# Altium schematic sheet Transceiver.SchDoc(Transceiver)
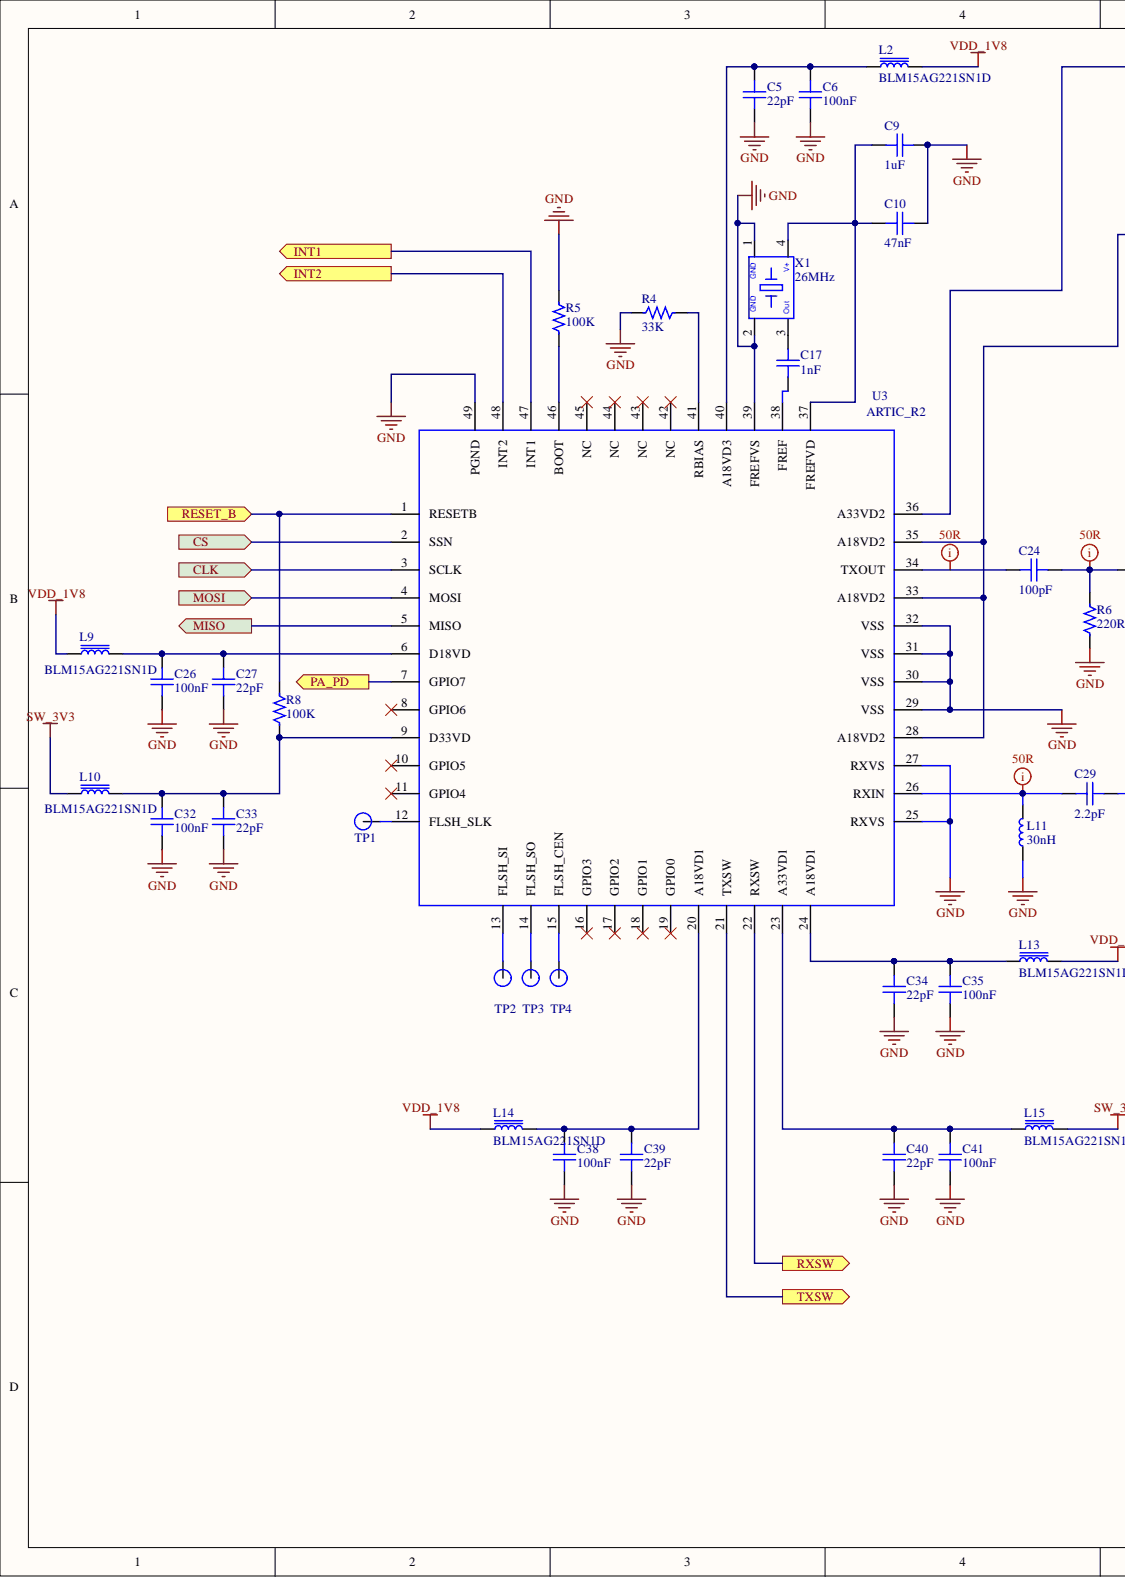
[diagram p0: Transceiver.SchDoc, left]
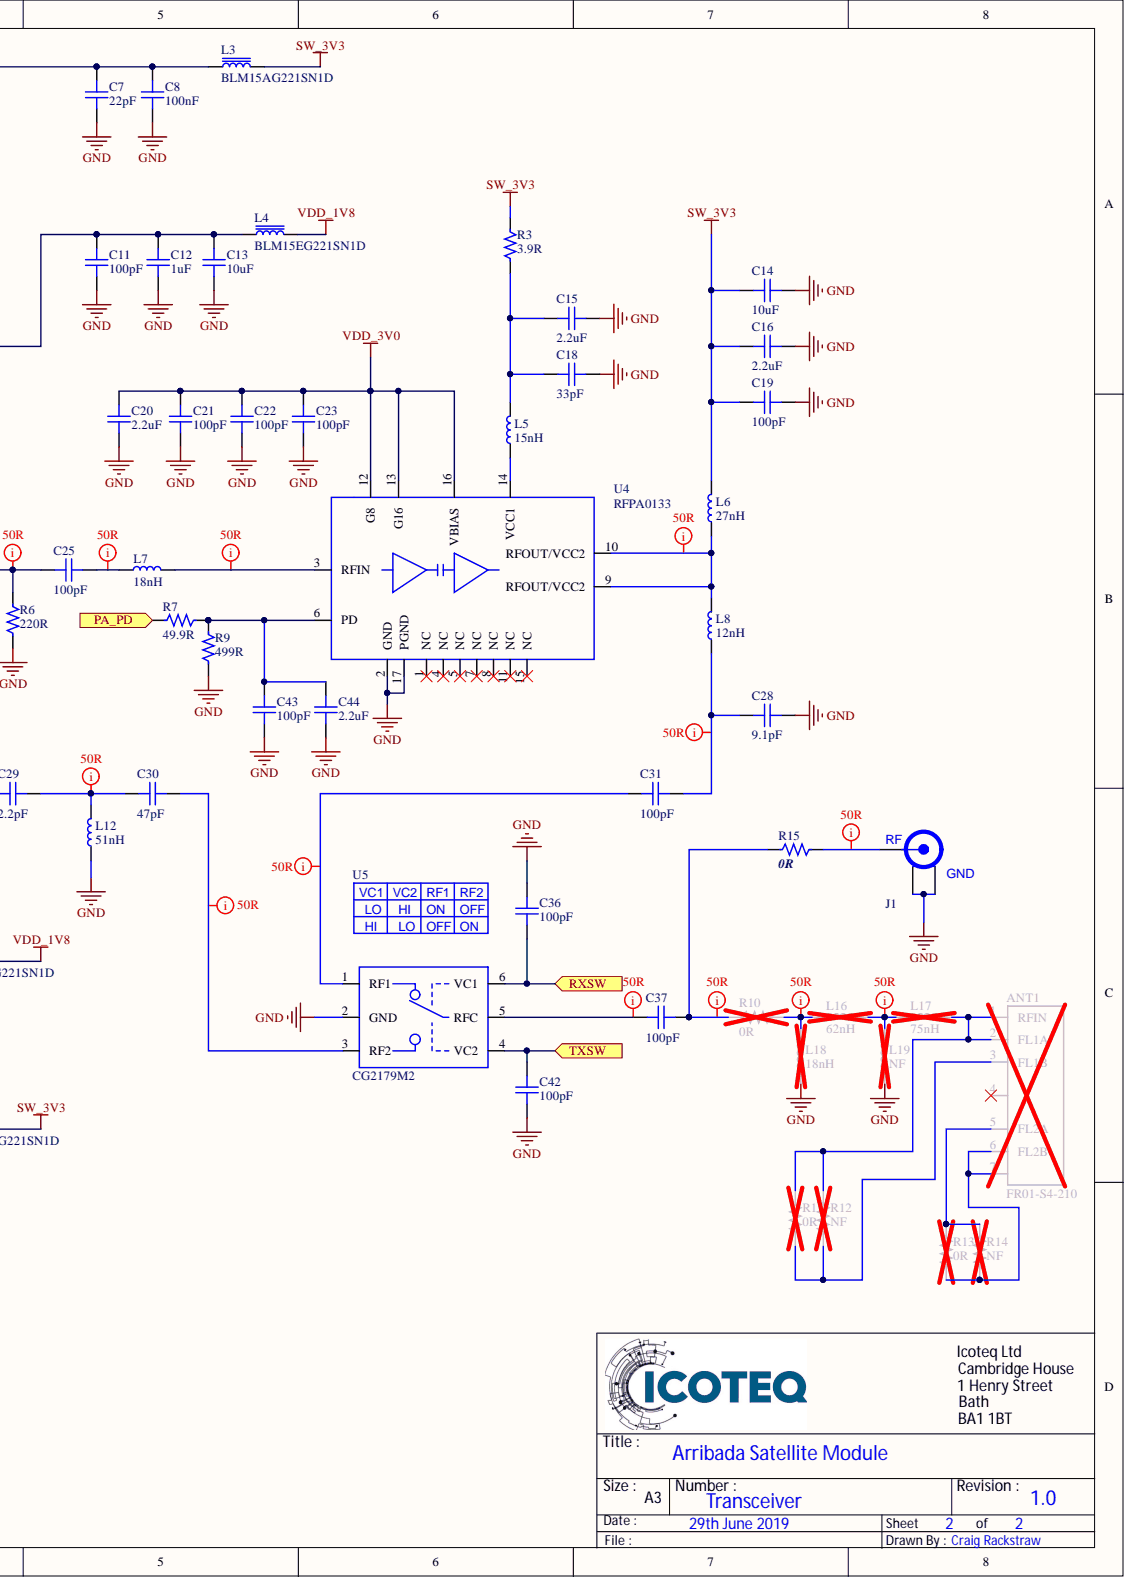
[diagram p1: Transceiver.SchDoc, right]

## Parts
ANT1  FR01-S4-210  [FR01-S4-210]
C5  value 22pF  [CAP0201L]  (hidden comment: CAP CER 22PF 0201 25V 5% C0G)
C6  value 100nF  [CAP0201L]  (hidden comment: 100NF 0201 35V 10% X5R)
C7  value 22pF  [CAP0201L]  (hidden comment: CAP CER 22PF 0201 25V 5% C0G)
C8  value 100nF  [CAP0201L]  (hidden comment: 100NF 0201 35V 10% X5R)
C9  value 1uF  [CAP0201L]  (hidden comment: 1UF 0201 10V 20% X5R)
C10  value 47nF  [CAP0201L]  (hidden comment: 47NF 0201 16V 10% X5R)
C11  value 100pF  [CAP0201L]  (hidden comment: 100PF 0201 25V 5% C0G)
C12  value 1uF  [CAP0201L]  (hidden comment: 1UF 0201 10V 20% X5R)
C13  value 10uF  [CAP0402L]  (hidden comment: 10UF 0402 6.3V 20% X5R)
C14  value 10uF  [CAP0402L]  (hidden comment: 10UF 0402 6.3V 20% X5R)
C15  value 2.2uF  [CAP0201L]  (hidden comment: 2.2UF 0201 6V3 20% X5R)
C16  value 2.2uF  [CAP0201L]  (hidden comment: 2.2UF 0201 6V3 20% X5R)
C17  value 1nF  [CAP0201L]  (hidden comment: 1NF 0201 10V 10% X5R)
C18  value 33pF  [CAP0201L]  (hidden comment: CAP CER 33PF 0201 25V 5% C0G)
C19  value 100pF  [CAP0201L]  (hidden comment: 100PF 0201 25V 5% C0G)
C20  value 2.2uF  [CAP0201L]  (hidden comment: 2.2UF 0201 6V3 20% X5R)
C21  value 100pF  [CAP0201L]  (hidden comment: 100PF 0201 25V 5% C0G)
C22  value 100pF  [CAP0201L]  (hidden comment: 100PF 0201 25V 5% C0G)
C23  value 100pF  [CAP0201L]  (hidden comment: 100PF 0201 25V 5% C0G)
C24  value 100pF  [CAP0402L]  (hidden comment: 100PF 0402 50V 5% C0G)
C25  value 100pF  [CAP0402L]  (hidden comment: 100PF 0402 50V 5% C0G)
C26  value 100nF  [CAP0201L]  (hidden comment: 100NF 0201 35V 10% X5R)
C27  value 22pF  [CAP0201L]  (hidden comment: CAP CER 22PF 0201 25V 5% C0G)
C28  value 9.1pF  [CAP0402L]  (hidden comment: 9.1pF 0402 50V +/-0.25pF C0G)
C29  value 2.2pF  [CAP0402L]  (hidden comment: 2.2pF 0402 50V +/-0.1pF C0G)
C30  value 47pF  [CAP0402L]  (hidden comment: 47pF 0402 50V 5% C0G)
C31  value 100pF  [CAP0402L]  (hidden comment: 100PF 0402 50V 5% C0G)
C32  value 100nF  [CAP0201L]  (hidden comment: 100NF 0201 35V 10% X5R)
C33  value 22pF  [CAP0201L]  (hidden comment: CAP CER 22PF 0201 25V 5% C0G)
C34  value 22pF  [CAP0201L]  (hidden comment: CAP CER 22PF 0201 25V 5% C0G)
C35  value 100nF  [CAP0201L]  (hidden comment: 100NF 0201 35V 10% X5R)
C36  value 100pF  [CAP0201L]  (hidden comment: 100PF 0201 25V 5% C0G)
C37  value 100pF  [CAP0402L]  (hidden comment: 100PF 0402 50V 5% C0G)
C38  value 100nF  [CAP0201L]  (hidden comment: 100NF 0201 35V 10% X5R)
C39  value 22pF  [CAP0201L]  (hidden comment: CAP CER 22PF 0201 25V 5% C0G)
C40  value 22pF  [CAP0201L]  (hidden comment: CAP CER 22PF 0201 25V 5% C0G)
C41  value 100nF  [CAP0201L]  (hidden comment: 100NF 0201 35V 10% X5R)
C42  value 100pF  [CAP0201L]  (hidden comment: 100PF 0201 25V 5% C0G)
C43  value 100pF  [CAP0201L]  (hidden comment: 100PF 0201 25V 5% C0G)
C44  value 2.2uF  [CAP0201L]  (hidden comment: 2.2UF 0201 6V3 20% X5R)
J1  20279-001E-01  [MHF SMT REC]  (value not drawn)
L2  BLM15AG221SN1D  [RES0402L]
L3  BLM15AG221SN1D  [RES0402L]
L4  BLM15EG221SN1D  [RES0402L]
L5  value 15nH  [Coilcraft 0402HP]  (hidden comment: 15nH 2% 1.1A 0402HP)
L6  value 27nH  [Coilcraft 0402HP]  (hidden comment: 27nH 2% 450mA 0402HP)
L7  value 18nH  [LQG15HN_HS]  (hidden comment: 18nH 5% 350MA 0402 MUR)
L8  value 12nH  [Coilcraft 0402HP]  (hidden comment: 12nH 2% 1.2A 0402HP)
L9  BLM15AG221SN1D  [RES0402L]
L10  BLM15AG221SN1D  [RES0402L]
L11  value 30nH  [Coilcraft 0402HP]  (hidden comment: 30nH 2% 450mA 0402HP)
L12  value 51nH  [Coilcraft 0402HP]  (hidden comment: 51nH 2% 360mA 0402HP)
L13  BLM15AG221SN1D  [RES0402L]
L14  BLM15AG221SN1D  [RES0402L]
L15  BLM15AG221SN1D  [RES0402L]
L16  value 62nH  [LQW15A_00/10]  (hidden comment: 62nH 2% 145MA 0402)
L17  value 75nH  [LQW15A_00/10]  (hidden comment: 75nH 2% 135MA 0402)
L18  value 18nH  [LQW15A_00/10]  (hidden comment: 18nH 2% 370MA 0402)
L19  value NF  [LQW15A_00/10]  (hidden comment: LQW15A NF)
R3  value 3.9R  [RES0402L]  (hidden comment: 3.9R 0402 1% 100PPM)
R4  value 33K  [RES0201L]  (hidden comment: 33K 0201 1% 100PPM)
R5  value 100K  [RES0201L]  (hidden comment: 100K 0201 1% 100PPM)
R6  value 220R  [RES0402L]  (hidden comment: 220R 0402 1% 100PPM)
R7  value 49.9R  [RES0201L]  (hidden comment: 49.9R 0201 1% 100PPM)
R8  value 100K  [RES0201N]  (hidden comment: 100K 0201 1% 100PPM)
R9  value 499R  [RES0201L]  (hidden comment: 499R 0201 1% 100PPM)
R10  value 0R  [RES0402L]  (hidden comment: 0R 0402)
R11  value 0R  [RES0402L]  (hidden comment: 0R 0402)
R12  value NF  [Passive0402L Not Fitted]  (hidden comment: RES NF 0402)
R13  value 0R  [RES0402L]  (hidden comment: 0R 0402)
R14  value NF  [Passive0402L Not Fitted]  (hidden comment: RES NF 0402)
R15  value 0R  [RES0402N]  (hidden comment: 0R 0402)
TP1  Test Point Pad 1mm  [TP1MM]  (value not drawn)
TP2  Test Point Pad 1mm  [TP1MM]  (value not drawn)
TP3  Test Point Pad 1mm  [TP1MM]  (value not drawn)
TP4  Test Point Pad 1mm  [TP1MM]  (value not drawn)
U3  ARTIC_R2  [QFN48_7MMx7MM]
U4  RFPA0133  [QFN50P300X300X86_HS-17N]
U5  CG2179M2  [SOT65P210X110-6N]
X1  value 26MHz  [Crystal 1.65mm x 1.25mm]  (hidden comment: LFTCXO073004)

## Ports
CLK, CS, INT1, INT2, MISO, MOSI, PA_PD, RESET_B, RXSW, TXSW

## Nets
GND: C5.1 C6.1 C7.1 C8.1 C9.2 C10.1 C11.1 C12.1 C13.1 C14.1 C15.1 C16.1 C18.2 C19.1 C20.1 C21.1 C22.1 C23.1 C26.1 C27.1 C28.1 C32.1 C33.1 C34.1 C35.1 C36.2 C38.1 C39.1 C40.1 C41.1 C42.1 C43.1 C44.1 J1.2 J1.3 L11.1 L12.1 L18.1 L19.1 R4.2 R5.2 R6.1 R9.1 U3.25(RXVS) U3.27(RXVS) U3.29(VSS) U3.30(VSS) U3.31(VSS) U3.32(VSS) U3.39(FREFVS) U3.49(PGND) U4.2(GND) U4.17(PGND) U5.2(GND) X1.1(GND) X1.2(GND)
SW_3V3: C14.2 C16.2 C19.2 L3.2 L6.2 L10.1 L15.1 R3.2
VDD_1V8: L2.2 L4.2 L9.1 L13.1 L14.1
VDD_3V0: C20.2 C21.2 C22.2 C23.2 U4.12(G8) U4.13(G16) U4.16(VBIAS)
CLK: U3.3(SCLK) ; port CLK
CS: U3.2(SSN) ; port CS
INT1: U3.47(INT1) ; port INT1
INT2: U3.48(INT2) ; port INT2
MISO: U3.5(MISO) ; port MISO
MOSI: U3.4(MOSI) ; port MOSI
PA_PD: R7.2 U3.7(GPIO7) ; port PA_PD
RESET_B: R8.2 U3.1(RESETB) ; port RESET_B
RXSW: C36.1 U3.22(RXSW) U5.6(VC1) ; port RXSW
TXSW: C42.2 U3.21(TXSW) U5.4(VC2) ; port TXSW
NetANT1_1: ANT1.1(RFIN) ANT1.2(FL1A) L17.2 R11.2 R12.2
NetANT1_3: ANT1.3(FL1B) R11.1 R12.1
NetANT1_5: ANT1.5(FL2A) R13.2 R14.2
NetANT1_6: ANT1.6(FL2B) ANT1.7 R13.1 R14.1
NetC5_2: C5.2 C6.2 L2.1 U3.40(A18VD3)
NetC7_2: C7.2 C8.2 L3.1 U3.36(A33VD2)
NetC9_1: C9.1 C10.2 U3.37(FREFVD) X1.4(V+)
NetC11_2: C11.2 C12.2 C13.2 L4.1 U3.28(A18VD2) U3.33(A18VD2) U3.35(A18VD2)
NetC15_2: C15.2 C18.1 L5.2 R3.1
NetC17_1: C17.1 U3.38(FREF)
NetC17_2: C17.2 X1.3(Out)
NetC24_1: C24.1 U3.34(TXOUT)
NetC24_2: C24.2 C25.1 R6.2
NetC25_2: C25.2 L7.1
NetC26_2: C26.2 C27.2 L9.2 U3.6(D18VD)
NetC28_2: C28.2 C31.2 L8.1
NetC29_1: C29.1 C30.1 L12.2
NetC29_2: C29.2 L11.2 U3.26(RXIN)
NetC30_2: C30.2 U5.3(RF2)
NetC31_1: C31.1 U5.1(RF1)
NetC32_2: C32.2 C33.2 L10.2 R8.1 U3.9(D33VD)
NetC34_2: C34.2 C35.2 L13.2 U3.24(A18VD1)
NetC37_1: C37.1 U5.5(RFC)
NetC37_2: C37.2 R10.1 R15.1
NetC38_2: C38.2 C39.2 L14.2 U3.20(A18VD1)
NetC40_2: C40.2 C41.2 L15.2 U3.23(A33VD1)
NetC43_2: C43.2 C44.2 R7.1 R9.2 U4.6(PD)
NetJ1_1: J1.1 R15.2
NetL5_1: L5.1 U4.14(VCC1)
NetL6_1: L6.1 L8.2 U4.9(RFOUT/VCC2) U4.10(RFOUT/VCC2)
NetL7_2: L7.2 U4.3(RFIN)
NetL16_1: L16.1 L18.2 R10.2
NetL16_2: L16.2 L17.1 L19.2
NetR4_1: R4.1 U3.41(RBIAS)
NetR5_1: R5.1 U3.46(BOOT)
NetTP1_1: TP1.1 U3.12(FLSH_SLK)
NetTP2_1: TP2.1 U3.13(FLSH_SI)
NetTP3_1: TP3.1 U3.14(FLSH_SO)
NetTP4_1: TP4.1 U3.15(FLSH_CEN)

Not connected: ANT1 4; U3 8(GPIO6) 10(GPIO5) 11(GPIO4) 16(GPIO3) 17(GPIO2) 18(GPIO1) 19(GPIO0) 42(NC) 43(NC) 44(NC) 45(NC); U4 1(NC) 4(NC) 5(NC) 7(NC) 8(NC) 11(NC) 15(NC)

## Library data of the parts
ANT1 = FR01-S4-210: ANT TRIO mXTEND 698-960MHz 1710-2690MHz; Manufacturer: Fractus; Supplier Part Number 2: 2115-FR01-S4-210CT-ND; Supplier Part Number 1: FR01-S4-210; Supplier 2: Digi-Key; Supplier 1: RichardsonRFPD; Manufacturers Part Number: FR01-S4-210; Library Name: Misc.SchLib; Distributor 2: Future Electronics; Distributor 1: EBV; Component Kind: Standard
C5 C7 C27 C33 C34 C39 C40 = CAP CER 22PF 0201 25V 5% C0G: Manufacturers Part Number: GRM0335C1E220JA01D; Manufacturer: Murata; Voltage: 25V; Tolerance: 5%; Supplier Part Number 2: 490-1253-1-ND; Supplier Part Number 1: 81-GRM0335C1E220JA1D; Supplier 2: Digi-Key; Supplier 1: Mouser; Size: 0201; Q @ 900MHz: -; Library Name: Capacitors.SchLib; Dielectric: C0G; Description: CAP CER 22PF 0201 25V 5% C0G; Component Kind: Standard
C6 C8 C26 C32 C35 C38 C41 = CAP CER 100NF 0201 35V 10% X5R: Manufacturers Part Number: GRM033R6YA104KE14D; Manufacturer: Murata; Tolerance: 10%; Supplier Part Number 2: 490-10430-1-ND; Supplier Part Number 1: 81-GRM33R6YA104KE14D; Supplier 2: Digi-Key; Supplier 1: Mouser; Size: 0201; Library Name: Capacitors.SchLib; Dielectric: X5R; Description: CAP CER 100NF 0201 35V 10% X5R; Component Kind: Standard
C9 C12 = CAP CER 1UF 0201 10V 20% X5R: Manufacturers Part Number: GRM033R61A105ME15D; Manufacturer: Murata; Voltage: 10V; Tolerance: 20%; Supplier Part Number 2: 490-13226-6-ND; Supplier Part Number 1: 81-GRM033R61A105ME5D; Supplier 2: Digi-Key; Supplier 1: Mouser; Size: 0201; Library Name: Capacitors.SchLib; Dielectric: X5R; Description: CAP CER 1UF 0201 10V 20% X5R; Component Kind: Standard
C10 = CAP CER 47NF 0201 16V 10% X5R: Manufacturers Part Number: GRM033R61C473KE84D; Manufacturer: Murata; Voltage: 16V; Tolerance: 10%; Supplier Part Number 2: 490-7227-1-ND; Supplier Part Number 1: 81-GRM033R61C473KE4D; Supplier 2: Digi-Key; Supplier 1: Mouser; Size: 0201; Library Name: Capacitors.SchLib; Dielectric: X5R; Description: CAP CER 47NF 0201 16V 10% X5R; Component Kind: Standard
C11 C19 C21-C23 C36 C42 C43 = CAP CER 100PF 0201 25V 5% C0G: Manufacturers Part Number: C0603C0G1E101J030BA; Manufacturer: TDK; Voltage: 25V; Tolerance: 5%; Supplier Part Number 2: 445-4675-1-ND; Supplier Part Number 1: 810-C0603C0G1E101J; Supplier 2: Digi-Key; Supplier 1: Mouser; Size: 0201; Library Name: Capacitors.SchLib; Dielectric: C0G; Description: CAP CER 100PF 0201 25V 5% C0G; Component Kind: Standard
C13 C14 = CAP CER 10UF 0402 6.3V 20% X5R: Manufacturers Part Number: JMK105CBJ106MV-F; Manufacturer: Taiyo Yuden; Voltage: 6.3V; Tolerance: 20%; Supplier Part Number 2: 587-5868-1-ND; Supplier Part Number 1: 963-JMK105CBJ106MV-F; Supplier 2: Digi-Key; Supplier 1: Mouser; Size: 0402; Library Name: Capacitors.SchLib; Dielectric: X5R; Description: CAP CER 10UF 0402 6.3V 20% X5R; Component Kind: Standard
C15 C16 C20 C44 = CAP CER 2.2UF 0201 6V3 20% X5R: Manufacturers Part Number: CL03A225MQ3CRNC; Manufacturer: Samsung; Voltage: 6V3; Tolerance: 20%; Supplier Part Number 2: 1276-6870-2-ND; Supplier Part Number 1: CL03A225MQ3CRNC; Supplier 2: Digi-Key; Supplier 1: Arrow; Size: 0201; Library Name: Capacitors.SchLib; Dielectric: X5R; Description: CAP CER 2.2UF 0201 6V3 20% X5R; Component Kind: Standard
C17 = CAP CER 1NF 0201 10V 10% X5R: Manufacturers Part Number: 0201ZD102KAT2A; Manufacturer: AVX; Voltage: 10V; Tolerance: 10%; Supplier Part Number 2: 0201ZD102KAT2A-ND; Supplier Part Number 1: 581-0201ZD102KAT2A; Supplier 2: Digi-Key; Supplier 1: Mouser; Size: 0201; Library Name: Capacitors.SchLib; Dielectric: X5R; Description: CAP CER 1NF 0201 10V 10% X5R; Component Kind: Standard
C18 = CAP CER 33PF 0201 25V 5% C0G: Manufacturers Part Number: 02013A330JAT2A; Manufacturer: AVX; Voltage: 25V; Tolerance: 5%; Supplier Part Number 2: 478-1020-1-ND; Supplier Part Number 1: 581-02013A330J; Supplier 2: Digi-Key; Supplier 1: Mouser; Size: 0201; Q @ 900MHz: -; Library Name: Capacitors.SchLib; Dielectric: C0G; Description: CAP CER 33PF 0201 25V 5% C0G; Component Kind: Standard
C24 C25 C31 C37 = CAP CER 100PF 0402 50V 5% C0G: Manufacturers Part Number: GRM1555C1H101JZ01D; Manufacturer: Murata; Voltage: 50V; Tolerance: 5%; Supplier Part Number 2: 490-3458-1-ND; Supplier Part Number 1: 81-GRM1555C1H101JZ1D; Supplier 2: Digi-Key; Supplier 1: Mouser; Size: 0402; Library Name: Capacitors.SchLib; Dielectric: C0G; Description: CAP CER 100PF 0402 50V 5% C0G; Component Kind: Standard
C28 = CAP CER 9.1pF 0402 50V +/-0.25pF C0G: Manufacturers Part Number: 500R07S9R1CV4T; Manufacturer: Johanson; Voltage: 50V; Tolerance: +/-0.25pF; Supplier Part Number 2: 712-1294-1-ND; Supplier Part Number 1: 609-500R07S9R1CV4T; Supplier 2: Digi-Key; Supplier 1: Mouser; Size: 0402; Library Name: Capacitors.SchLib; Dielectric: C0G; Description: CAP CER 9.1pF 0402 50V +/-0.25pF C0G; Component Kind: Standard
C29 = CAP CER 2.2pF 0402 50V +/-0.1pF C0G: Manufacturers Part Number: GJM1555C1H2R2BB01D; Manufacturer: Murata; Voltage: 50V; Tolerance: +/-0.1pF; Supplier Part Number 2: 490-8092-1-ND; Supplier Part Number 1: 81-GJM1555C1H2R2BB01; Supplier 2: Digi-Key; Supplier 1: Mouser; Size: 0402; Library Name: Capacitors.SchLib; Dielectric: C0G; Description: CAP CER 2.2pF 0402 50V +/-0.1pF C0G; Component Kind: Standard
C30 = CAP CER 47pF 0402 50V 5% C0G: Manufacturers Part Number: GRM1555C1H470JZ01D; Manufacturer: Murata; Dielectric: CH; Voltage: 50V; Tolerance: 5%; Supplier Part Number 2: 490-1287-1-ND; Supplier Part Number 1: 81-GRM36C470J50; Supplier 2: Digi-Key; Supplier 1: Mouser; Size: 0402; Library Name: Capacitors.SchLib; Description: CAP CER 47pF 0402 50V 5% C0G; Component Kind: Standard
J1 = 20279-001E-01: CON IPEX MHF SMT RECEPTACLE RF DC - 6GHZ VSWR: 1.3 max. at 0.1-3GHz; VSWR: 1.5 max. at 3-6GHz; Manufacturers Part Number: RECE-20279-001E-01; Manufacturer: Taoglas; Supplier Part Number 2: 960-RECE20279001E01; Supplier Part Number 1: 931-1107-1-ND; Supplier 2: Mouser; Supplier 1: Digi-Key; Size: MHF; Library Name: Connectors.SchLib; Frequency Range: DC - 6GHz; Distributor 2: Future Electronics; Distributor 1: EBV; Component Kind: Standard
L2 L3 L9 L10 L13-L15 = BLM15AG221SN1D: IND FER 220OHM@100MHZ 450MA 25% 0402; Manufacturer: Murata; Value: BLM15AG221SN1D; Tolerance: 25%; Supplier Part Number 2: 490-5178-1-ND; Supplier Part Number 1: 81-BLM15AG221SN1D; Supplier 2: Digi-Key; Supplier 1: Mouser; Size: 0402; Manufacturers Part Number: BLM15AG221SN1D; Library Name: Inductors.SchLib; Component Kind: Standard
L4 = BLM15EG221SN1D: IND FER 220OHM@100MHZ 700MA 25% 0402; Manufacturer: Murata; Value: BLM15EG221SN1D; Tolerance: 25%; Supplier Part Number 2: 490-4005-1-ND; Supplier Part Number 1: 81-BLM15EG221SN1D; Supplier 2: Digi-Key; Supplier 1: Mouser; Size: 0402; Manufacturers Part Number: BLM15EG221SN1D; Library Name: Inductors.SchLib; Component Kind: Standard
L5 = 15nH 2% 1.1A 0402HP: IND 15nH 2% 1.1A 0402HP; Manufacturers Part Number: 0402HP-15NXGLU; Manufacturer: Coilcraft; Q @ 900MHz: 75; Q @ 1.7GHz: 95; Tolerance: 2%; Supplier Part Number 2: 2285786; Supplier Part Number 1: 994-0402HP-15NXGLU; Supplier 2: Farnell; Supplier 1: Mouser; Library Name: Inductors.SchLib; Component Kind: Standard
L6 = 27nH 2% 450mA 0402HP: IND 27nH 2% 450mA 0402HP; Manufacturers Part Number: 0402HP-27NXGLU; Manufacturer: Coilcraft; Q @ 900MHz: 63; Q @ 1.7GHz: 85; Tolerance: 2%; Supplier Part Number 2: 2285798; Supplier Part Number 1: 994-0402HP-27NXGLU; Supplier 2: Farnell; Supplier 1: Mouser; Library Name: Inductors.SchLib; Component Kind: Standard
L7 = 18nH 5% 350MA 0402 MUR: IND 18nH 5% 350MA 0402 MUR; Manufacturers Part Number: LQG15HN18NJ02D; Manufacturer: Murata; Tolerance: 5%; Supplier Part Number 2: 490-1088-1-ND; Supplier Part Number 1: 81-LQG15HN18NJ02D; Supplier 2: Digi-Key; Supplier 1: Mouser; Size: 0402; Library Name: Inductors.SchLib; Component Kind: Standard
L8 = 12nH 2% 1.2A 0402HP: IND 12nH 2% 1.2A 0402HP; Manufacturers Part Number: 0402HP-12NXGLU; Manufacturer: Coilcraft; Q @ 900MHz: 75; Q @ 1.7GHz: 100; Tolerance: 2%; Supplier Part Number 2: 2285784; Supplier Part Number 1: 994-0402HP-12NXGLU; Supplier 2: Farnell; Supplier 1: Mouser; Library Name: Inductors.SchLib; Component Kind: Standard
L11 = 30nH 2% 450mA 0402HP: IND 30nH 2% 450mA 0402HP; Manufacturers Part Number: 0402HP-30NXGLU; Manufacturer: Coilcraft; Q @ 900MHz: 63; Q @ 1.7GHz: 80; Tolerance: 2%; Supplier Part Number 2: 2285799; Supplier Part Number 1: 994-0402HP-30NXGLU; Supplier 2: Farnell; Supplier 1: Mouser; Library Name: Inductors.SchLib; Component Kind: Standard
L12 = 51nH 2% 360mA 0402HP: IND 51nH 2% 360mA 0402HP; Manufacturers Part Number: 0402HP-51NXGLU; Manufacturer: Coilcraft; Q @ 900MHz: 55; Q @ 1.7GHz: 62; Tolerance: 2%; Supplier Part Number 2: 2285808; Supplier Part Number 1: 994-0402HP-51NXGLU; Supplier 2: Farnell; Supplier 1: Mouser; Library Name: Inductors.SchLib; Component Kind: Standard
L16 = 62nH 2% 145MA 0402: IND 62nH 2% 145MA 0402; Manufacturers Part Number: LQW15AN62NG; Manufacturer: Murata; Q @ 900MHz: 53; Tolerance: 2%; Supplier Part Number 2: 2470384; Supplier Part Number 1: 81-LQW15AN62NG00D; Supplier 2: Farnell; Supplier 1: Mouser; Size: 0402; Q @ 1.8GHz: 53; Library Name: Inductors.SchLib; Component Kind: Standard
L17 = 75nH 2% 135MA 0402: IND 75nH 2% 135MA 0402; Manufacturers Part Number: LQW15AN75NG; Manufacturer: Murata; Q @ 900MHz: 53; Tolerance: 2%; Supplier Part Number 2: LQW15AN75NG00D; Supplier Part Number 1: 81-LQW15AN75NG00D; Supplier 2: Digi-Key; Supplier 1: Mouser; Size: 0402; Q @ 1.8GHz: 53; Library Name: Inductors.SchLib; Component Kind: Standard
L18 = 18nH 2% 370MA 0402: IND 18nH 2% 370MA 0402; Manufacturers Part Number: LQW15AN18NG; Manufacturer: Murata; Q @ 900MHz: 65; Q @ 1.8GHz: 88; Tolerance: 2%; Supplier Part Number 2: 1762622; Supplier Part Number 1: 81-LQW15AN18NG0ZD; Supplier 2: Farnell; Supplier 1: Mouser; Size: 0402; Library Name: Inductors.SchLib; Component Kind: Standard
L19 = LQW15A NF: IND LQW15A NF; Manufacturers Part Number: NOT FITTED PART; Supplier Part Number 2: NOT FITTED PART; Supplier Part Number 1: NOT FITTED PART; Supplier 2: NOT FITTED PART; Supplier 1: NOT FITTED PART; Size: 0402; Manufacturer: NOT FITTED PART; Library Name: Inductors.SchLib; Component Kind: Standard
R3 = RES 3.9R 0402 1% 100PPM: PPM: 100; Tolerance: 1%; Supplier Part Number 2: RC0402FR-073R9L-ND; Supplier Part Number 1: 603-RC0402FR-073R9L; Supplier 2: Digi-Key; Supplier 1: Mouser; Size: 0402; Library Name: Resistors.SchLib; Description: RES 3.9R 0402 1% 100PPM; Component Kind: Standard
R4 = RES 33K 0201 1% 100PPM: PPM: 100; Tolerance: 1%; Supplier Part Number 2: 541-33.0KAABCT-ND; Supplier Part Number 1: 71-CRCW020133K0FKED; Supplier 2: Digi-Key; Supplier 1: Mouser; Size: 0201; Library Name: Resistors.SchLib; Description: RES 33K 0201 1% 100PPM; Component Kind: Standard
R5 R8 = RES 100K 0201 1% 100PPM: PPM: 100; Tolerance: 1%; Supplier Part Number 2: 541-100KAABCT-ND; Supplier Part Number 1: 71-CRCW0201100KFKED; Supplier 2: Digi-Key; Supplier 1: Mouser; Size: 0201; Library Name: Resistors.SchLib; Description: RES 100K 0201 1% 100PPM; Component Kind: Standard
R6 = RES 220R 0402 1% 100PPM: PPM: 100; Tolerance: 1%; Supplier Part Number 2: 541-4064-1-ND; Supplier Part Number 1: 71-CRCW0402220RFKEDC; Supplier 2: Digi-Key; Supplier 1: Mouser; Size: 0402; Library Name: Resistors.SchLib; Description: RES 220R 0402 1% 100PPM; Component Kind: Standard
R7 = RES 49.9R 0201 1% 100PPM: PPM: 100; Tolerance: 1%; Supplier Part Number 2: 541-49.9AABCT-ND; Supplier Part Number 1: 71-CRCW020149R9FKED; Supplier 2: Digi-Key; Supplier 1: Mouser; Size: 0201; Library Name: Resistors.SchLib; Description: RES 49.9R 0201 1% 100PPM; Component Kind: Standard
R9 = RES 499R 0201 1% 100PPM: PPM: 100; Tolerance: 1%; Supplier Part Number 2: 541-499AABCT-ND; Supplier Part Number 1: 71-CRCW0201499RFKED; Supplier 2: Digi-Key; Supplier 1: Mouser; Size: 0201; Library Name: Resistors.SchLib; Description: RES 499R 0201 1% 100PPM; Component Kind: Standard
R10 R11 R13 R15 = RES 0R 0402: Supplier Part Number 2: 311-0.0JRCT-ND; Supplier Part Number 1: 603-RC0402JR-070RL; Supplier 2: Digi-Key; Supplier 1: Mouser; Size: 0402; Library Name: Resistors.SchLib; Description: RES 0R 0402; Component Kind: Standard
  R15: Manufacturers Part Number: *; Manufacturer: *
R12 R14 = RES NF 0402: Manufacturers Part Number: NOT FITTED PART; Supplier 2: Digi-Key; Supplier 1: Mouser; Size: 0402; Manufacturer: NOT FITTED PART; Library Name: Resistors.SchLib; Description: RES NF 0402; Component Kind: Standard
TP1-TP4 = Test Point Pad 1mm: Manufacturers Part Number: NOT FITTED PART; Manufacturer: NOT FITTED PART; Library Name: Misc.SchLib; Distributor 2: Future Electronics; Distributor 1: EBV; Description: Test Point Pad 1mm; Component Kind: Standard
U3 = ARTIC_R2: IC XCVR ARTIC R2 QFN48; Manufacturer: AnSem; Temp Min: -40; Temp Max: 85; Manufacturers Part Number: ARTIC_R2; Library Name: ICs.SchLib; Component Kind: Standard
U4 = RFPA0133: IC RF AMP 1W GAIN 32dB 380MHz-960MHz QFN16; Manufacturer: Qorvo; Temp Min: -40; Temp Max: 85; Supplier Part Number 2: 772-RFPA0133; Supplier Part Number 1: 2066376; Supplier 2: Mouser; Supplier 1: Farnell; Manufacturers Part Number: RFPA0133; Library Name: ICs.SchLib; Component Kind: Standard
U5 = CG2179M2: IC SWITCH SPDT  50MHz-3.0 GHz Minimold-6; Manufacturer: CEL; Temp Min: -40; Temp Max: 85; Supplier Part Number 2: 551-CG2179M2-C4; Supplier Part Number 1: CG2179M2-C4CT-ND; Supplier 2: Mouser; Supplier 1: Digi-Key; Manufacturers Part Number: CG2179M2; Library Name: ICs.SchLib; Component Kind: Standard
X1 = LFTCXO073004: XTAL 26MHz 0.5PPM 10PF 1.65MM x 1.25MM; Manufacturer: IQD; Mode: Fundamental; Temp Min: -30; Temp Max: 85; Supplier Part Number 2: 1923-1725-1-ND; Supplier Part Number 1: 449-LFTCXO073004CUTT; Supplier 2: Digi-Key; Supplier 1: Mouser; Manufacturers Part Number: LFTCXO073004; Load Capacitance: 10pF; Library Name: Crystals.SchLib; Frequency Stability: 0.5PPM; Distributor 2: Future Electronics; Distributor 1: EBV; Component Kind: Standard
